# Altium schematic sheet Sheet1_V2.SchDoc(Sheet1_V2)
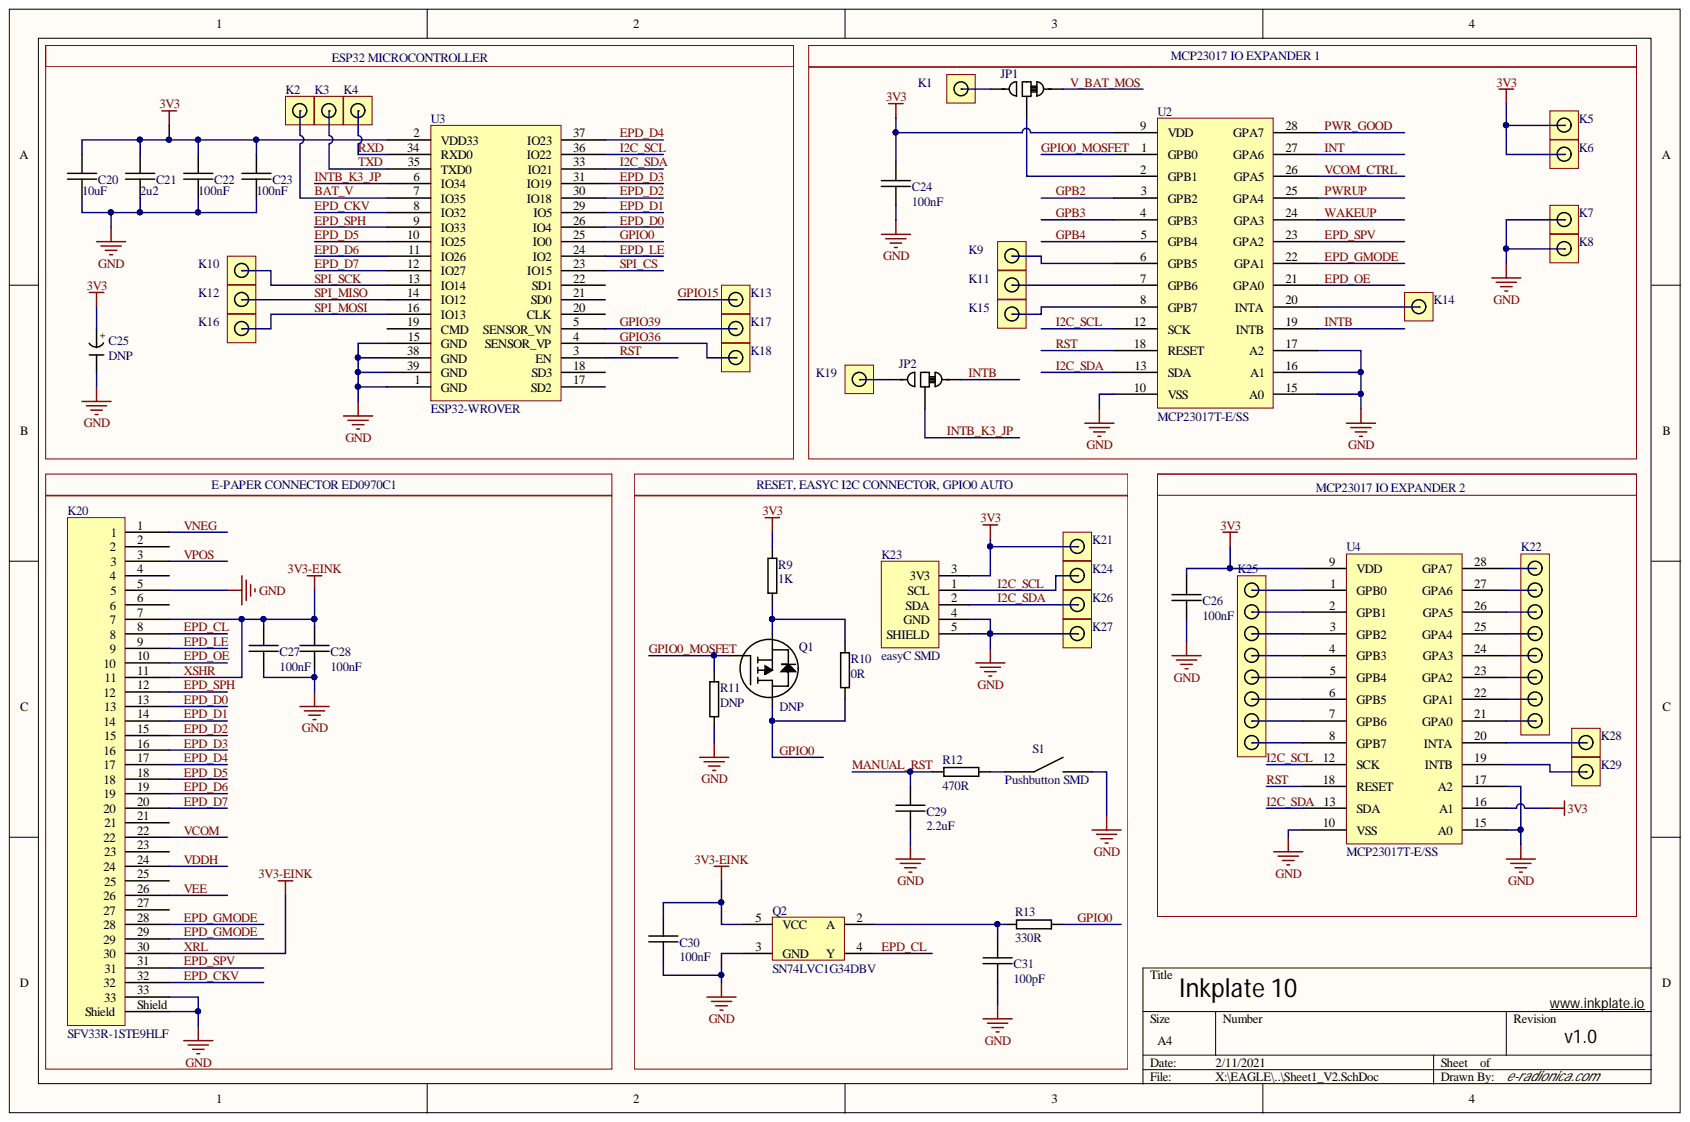
[diagram p0: Sheet1_V2.SchDoc]

## Parts
C20  10uF  [1206C]
C21  2u2  [0603C]
C22  100nF  [0603C]
C23  100nF  [0603C]
C24  100nF  [0603C]
C25  DNP  [2917C]
C26  100nF  [0603C]
C27  100nF  [0603C]
C28  100nF  [0603C]
C29  2.2uF  [0603C]
C30  100nF  [0603C]
C31  100pF  [0603C]
JP1  2/3 pads connected  [SMD_JUMPER 2/3 pads]  (value not drawn)
JP2  2/3 pads connected  [SMD_JUMPER 2/3 pads]  (value not drawn)
K1  HEADER 1x1  [HEADER MALE 1x1]  (value not drawn)
K2  HEADER 1x1  [HEADER MALE 1x1]  (value not drawn)
K3  HEADER 1x1  [HEADER MALE 1x1]  (value not drawn)
K4  HEADER 1x1  [HEADER MALE 1x1]  (value not drawn)
K5  HEADER 1x1  [HEADER MALE 1x1]  (value not drawn)
K6  HEADER 1x1  [HEADER MALE 1x1]  (value not drawn)
K7  HEADER 1x1  [HEADER MALE 1x1]  (value not drawn)
K8  HEADER 1x1  [HEADER MALE 1x1]  (value not drawn)
K9  HEADER 1x1  [HEADER MALE 1x1]  (value not drawn)
K10  HEADER 1x1  [HEADER MALE 1x1]  (value not drawn)
K11  HEADER 1x1  [HEADER MALE 1x1]  (value not drawn)
K12  HEADER 1x1  [HEADER MALE 1x1]  (value not drawn)
K13  HEADER 1x1  [HEADER MALE 1x1]  (value not drawn)
K14  HEADER 1x1  [HEADER MALE 1x1]  (value not drawn)
K15  HEADER 1x1  [HEADER MALE 1x1]  (value not drawn)
K16  HEADER 1x1  [HEADER MALE 1x1]  (value not drawn)
K17  HEADER 1x1  [HEADER MALE 1x1]  (value not drawn)
K18  HEADER 1x1  [HEADER MALE 1x1]  (value not drawn)
K19  HEADER 1x1  [HEADER MALE 1x1]  (value not drawn)
K20  SFV33R-1STE9HLF  [SFV33R-1STE9HLF]
K21  3V3  [HEADER MALE 1x1]  (value not drawn)
K22  HEADER 8x1  [HEADER MALE 8x1]  (value not drawn)
K23  easyC SMD  [EasyC connector]
K24  SCL  [HEADER MALE 1x1]  (value not drawn)
K25  HEADER 8x1  [HEADER MALE 8x1]  (value not drawn)
K26  SDA  [HEADER MALE 1x1]  (value not drawn)
K27  GND  [HEADER MALE 1x1]  (value not drawn)
K28  HEADER 1x1  [HEADER MALE 1x1]  (value not drawn)
K29  HEADER 1x1  [HEADER MALE 1x1]  (value not drawn)
Q1  DNP  [SOT-23-3]
Q2  SN74LVC1G34DBV  [SOT-23-5]
R9  1K  [0603R]
R10  0R  [0603R]
R11  DNP  [0603R]
R12  470R  [0603R]
R13  330R  [0603R]
S1  Pushbutton SMD  [Pushbutton SMD]
U2  MCP23017T-E/SS  [SSOP-28 10.20x5.30mm]
U3  ESP32-WROVER  [ESP32-WROVER]
U4  MCP23017T-E/SS  [SSOP-28 10.20x5.30mm]

## Nets
3V3: C20.2 C21.2 C22.2 C23.2 C24.2 C25.1 C26.2 K5.1 K6.1 K21.1 K23.3(3V3) R9.2 U2.9(VDD) U3.2(VDD33) U4.9(VDD) U4.16(A1)
GND: C20.1 C21.1 C22.1 C23.1 C24.1 C25.2 C26.1 C27.1 C28.1 C29.1 C30.1 C31.1 K7.1 K8.1 K20.5 K20.33 K20.Shield K23.4(GND) K23.5(SHIELD) K27.1 Q2.3(GND) R11.1 S1.2 U2.10(VSS) U2.15(A0) U2.16(A1) U2.17(A2) U3.1(GND) U3.15(GND) U3.38(GND) U3.39(GND) U4.10(VSS) U4.15(A0) U4.17(A2)
BAT_V: K2.1 U3.7(IO35)
EPD_CKV: K20.32 U3.8(IO32)
EPD_CL: K20.8 Q2.4(Y)
EPD_D0: K20.13 U3.26(IO4)
EPD_D1: K20.14 U3.29(IO5)
EPD_D2: K20.15 U3.30(IO18)
EPD_D3: K20.16 U3.31(IO19)
EPD_D4: K20.17 U3.37(IO23)
EPD_D5: K20.18 U3.10(IO25)
EPD_D6: K20.19 U3.11(IO26)
EPD_D7: K20.20 U3.12(IO27)
EPD_GMODE: K20.28 K20.29 U2.22(GPA1)
EPD_LE: K20.9 U3.24(IO2)
EPD_OE: K20.10 U2.21(GPA0)
EPD_SPH: K20.12 U3.9(IO33)
EPD_SPV: K20.31 U2.23(GPA2)
GPB2: U2.3(GPB2)
GPB3: U2.4(GPB3)
GPB4: U2.5(GPB4)
GPIO0: Q1.3 R10.1 R13.2 U3.25(IO0)
GPIO0_MOSFET: Q1.1 R11.2 U2.1(GPB0)
GPIO15: K13.1
GPIO36: K18.1 U3.4(SENSOR_VP)
GPIO39: K17.1 U3.5(SENSOR_VN)
I2C_SCL: K23.1(SCL) K24.1 U2.12(SCK) U3.36(IO22) U4.12(SCK)
I2C_SDA: K23.2(SDA) K26.1 U2.13(SDA) U3.33(IO21) U4.13(SDA)
INT: U2.27(GPA6)
INTB: JP2.3 U2.19(INTB)
INTB_K3_JP: JP2.2 U3.6(IO34)
MANUAL_RST: C29.2 R12.2
PWR_GOOD: U2.28(GPA7)
PWRUP: U2.25(GPA4)
RST: U2.18(RESET) U3.3(EN) U4.18(RESET)
RXD: K4.1 U3.34(RXD0)
SPI_CS: U3.23(IO15)
SPI_MISO: K12.1 U3.14(IO12)
SPI_MOSI: K16.1 U3.16(IO13)
SPI_SCK: K10.1 U3.13(IO14)
TXD: K3.1 U3.35(TXD0)
V_BAT_MOS: JP1.3
VCOM: K20.22
VCOM_CTRL: U2.26(GPA5)
VDDH: K20.24
VEE: K20.26
VNEG: K20.1
VPOS: K20.3
WAKEUP: U2.24(GPA3)
XRL: C27.2 C28.2 C30.2 K20.7 K20.11 K20.30 Q2.5(VCC)
NetC31_2: C31.2 Q2.2(A) R13.1
NetJP1_1: JP1.1 K1.1
NetJP1_2: JP1.2 U2.2(GPB1)
NetJP2_1: JP2.1 K19.1
NetK9_1: K9.1 U2.6(GPB5)
NetK11_1: K11.1 U2.7(GPB6)
NetK14_1: K14.1 U2.20(INTA)
NetK15_1: K15.1 U2.8(GPB7)
NetK22_1: K22.1 U4.28(GPA7)
NetK22_2: K22.2 U4.27(GPA6)
NetK22_3: K22.3 U4.26(GPA5)
NetK22_4: K22.4 U4.25(GPA4)
NetK22_5: K22.5 U4.24(GPA3)
NetK22_6: K22.6 U4.23(GPA2)
NetK22_7: K22.7 U4.22(GPA1)
NetK22_8: K22.8 U4.21(GPA0)
NetK25_1: K25.1 U4.1(GPB0)
NetK25_2: K25.2 U4.2(GPB1)
NetK25_3: K25.3 U4.3(GPB2)
NetK25_4: K25.4 U4.4(GPB3)
NetK25_5: K25.5 U4.5(GPB4)
NetK25_6: K25.6 U4.6(GPB5)
NetK25_7: K25.7 U4.7(GPB6)
NetK25_8: K25.8 U4.8(GPB7)
NetK28_1: K28.1 U4.20(INTA)
NetK29_1: K29.1 U4.19(INTB)
NetQ1_2: Q1.2 R9.1 R10.2
NetR12_1: R12.1 S1.1

Not connected: K20 2 4 6 21 23 25 27; U3 17(SD2) 18(SD3) 19(CMD) 20(CLK) 21(SD0) 22(SD1)

## Library data of the parts
C20 = 1206C: Component Kind: Standard; Library Name: e-radionica.com schematics.SchLib
C21-C24 C26-C31 = 0603C: Component Kind: Standard; Library Name: e-radionica.com schematics.SchLib
C25 = 2917C: Component Kind: Standard; Library Name: e-radionica.com schematics.SchLib
JP1 JP2 = SMD_JUMPER 2/3 pads connected: Component Kind: Standard; Library Name: e-radionica.com schematics.SchLib
K20 = SFV33R-1STE9HLF: Inkplate; Component Kind: Standard; Library Name: e-radionica.com schematics.SchLib
K21 K24 K26 K27 = HEADER 1x1: Component Kind: Standard; Library Name: e-radionica.com schematics.SchLib
Q1 = PMOS SOT-23-3: Supplier Part Number 3: DMP3120L-7; Supplier Part Number 2: 621-DMP3120L-7; Supplier Part Number 1: DMP3120L-7DI-ND; Supplier 3: Arrow; Supplier 2: Mouser; Supplier 1: Digi-Key; Component Kind: Standard; Library Name: e-radionica.com schematics.SchLib
Q2 = SN74LVC1G34DBV: Inkplate; Component Kind: Standard; Library Name: e-radionica.com schematics.SchLib
R9-R13 = 0603R: Component Kind: Standard; Library Name: e-radionica.com schematics.SchLib
U2 U4 = MCP23017_SS: Component Kind: Standard; Library Name: e-radionica.com schematics.SchLib
K1-K19 K28 K29 = HEADER 1x1: Component Kind: Standard; Library Name: e-radionica.com schematics.SchLib
K22 K25 = HEADER 8x1: Component Kind: Standard; Library Name: e-radionica.com schematics.SchLib
K23 = easyC SMD: Component Kind: Standard; Library Name: e-radionica.com schematics.SchLib
S1 = Pushbutton SMD: Component Kind: Standard; Library Name: e-radionica.com schematics.SchLib
U3 = ESP32-WROVER: Component Kind: Standard; Library Name: e-radionica.com schematics.SchLib
